# Altium schematic sheet Deck.SchDoc(Deck)
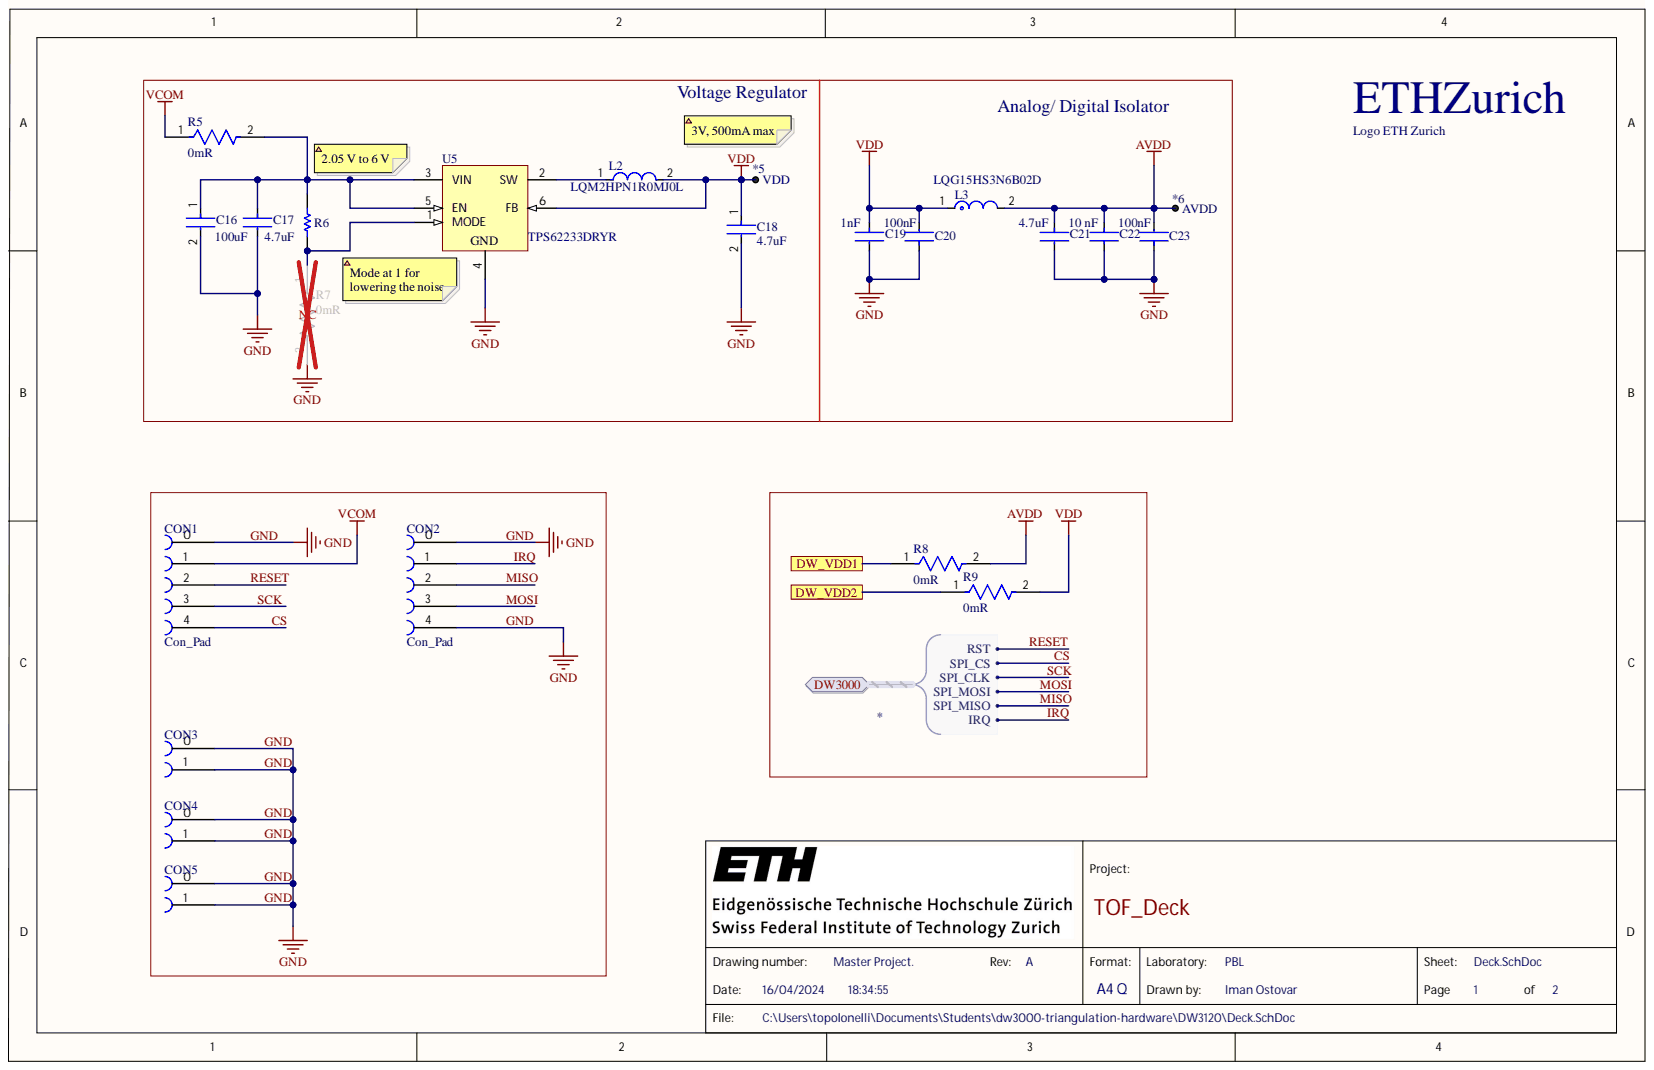
[diagram p0: Deck.SchDoc]

## Parts
*5  VDD  [Pad-1mm]  (no BOM)
*6  AVDD  [Pad-1mm]  (no BOM)
A1  Logo ETH Zurich  [eth-zurich_3mm]  (no BOM)
C16  Capacitance: 100uF  [FP-GRM21B-0_2-e0_2_0_7-IPC_A]  (hidden comment: GRM21BR60J107ME15L)
C17  Capacitance: 4.7uF  [FP-GRM155-0_1-IPC_B]  (hidden comment: GRM155R60J475ME47D)
C18  Capacitance: 4.7uF  [FP-GRM155-0_1-IPC_B]  (hidden comment: GRM155R60J475ME47D)
C19  Capacitance: 1nF  [FP-GRM155-0_05-IPC_B]  (hidden comment: GRM1555C1H102JA01D)
C20  Capacitance: 100nF  [FP-GRM155-0_05-IPC_B]  (hidden comment: GRM155R71E104ME14D)
C21  Capacitance: 4.7uF  [FP-GRM155-0_1-IPC_B]  (hidden comment: GRM155R60J475ME47D)
C22  value 10 nF  [FP-CC0402-MFG]  (hidden comment: CC0402KRX7R7BB103)
C23  Capacitance: 100nF  [FP-GRM155-0_05-IPC_B]  (hidden comment: GRM155R71E104ME14D)
CON1  Con_Pad  [Cons_Pad]
CON2  Con_Pad  [Cons_Pad]
CON3  Con_Pad_GND  [PCBComponent_1]
CON4  Con_Pad_GND  [PCBComponent_1]
CON5  Con_Pad_GND  [PCBComponent_1]
L2  LQM2HPN1R0MJ0L  [FP-LQM2HPN_J0-IPC_B]
L3  LQG15HS3N6B02D  [FP-LQG15HH_02-IPC_A]
R5  Resistance: 0mR  [FP-CRCW0402-e3-IPC_C]  (hidden comment: CRCW04020000Z0ED)
R6  CPF0402B47K5E1  [RESC1005X35X20LL05T10]  (value not drawn)
R7  Resistance: 0mR  [FP-CRCW0402-e3-IPC_C]  (hidden comment: CRCW04020000Z0ED)
R8  Resistance: 0mR  [FP-CRCW0402-e3-IPC_C]  (hidden comment: CRCW04020000Z0ED)
R9  Resistance: 0mR  [FP-CRCW0402-e3-IPC_C]  (hidden comment: CRCW04020000Z0ED)
U5  TPS62233DRYR  [DRY0006A]

## Ports
DW3000, DW3000.IRQ, DW3000.RST, DW3000.SPI_CLK, DW3000.SPI_CS, DW3000.SPI_MISO, DW3000.SPI_MOSI, DW_VDD1, DW_VDD2

## Nets
AVDD: *6.1 C21.1 C22.1 C23.2 L3.2 R8.2
VCOM: CON1.1 R5.1
VDD: *5.1 C18.1 C19.1 C20.2 L2.2 L3.1 R9.2 U5.6(FB)
CS: CON1.4 ; port DW3000
DW_VDD1: R8.1 ; port DW_VDD1
DW_VDD2: R9.1 ; port DW_VDD2
GND: C16.2 C17.2 C18.2 C19.2 C20.1 C21.2 C22.2 C23.1 CON1.0 CON2.0 CON2.4 CON3.0 CON3.1 CON4.0 CON4.1 CON5.0 CON5.1 R7.2 U5.4
IRQ: CON2.1 ; port DW3000
MISO: CON2.2 ; port DW3000
MOSI: CON2.3 ; port DW3000
RESET: CON1.2 ; port DW3000
SCK: CON1.3 ; port DW3000
NetC16_1: C16.1 C17.1 R5.2 R6.2 U5.3(VIN) U5.5(EN)
NetL2_1: L2.1 U5.2(SW)
NetR6_1: R6.1 R7.1 U5.1(MODE)

## Library data of the parts
*5 *6 = CMP-01283-1: 1mm SMT Test Pad; Library Name: ETHZ Vault; Mounting Technology: SMT
A1 = CMP-00038-1: Library Name: ETHZ Vault
C16 = CMP-2000-07599-2: Chip Multilayer Ceramic Capacitors for General Purpose, 0805, 100uF, X5R, 15%, 20%, 6.3V; Case Code (Imperial): 0805; Case Code (Metric): 2012; Case/Package: 0805; Component Kind: Standard; Dielectric: X5R; Lead Free: Lead Free; Length: 0.079inch; Library Name: Altium Content Vault; Max Operating Temperature: 85°C; Min Operating Temperature: -55°C; Mount: Surface Mount; Packaging: Cut Tape; REACH SVHC: No SVHC; Thickness: 0.057inch; Tolerance: 20%; Voltage Rating: 6.3V; Voltage Rating (DC): 6.3V; Width: 0.049inch
C17 C18 C21 = CMP-2008-04549-2: Chip Multilayer Ceramic Capacitors for General Purpose, 0402, 4.7uF, X5R, 15%, 20%, 6.3V; Case Code (Imperial): 0402; Case Code (Metric): 1005; Case/Package: 0402; Component Kind: Standard; Contact Plating: Tin; Depth: 0.5mm; Dielectric: X5R; Height: 0.5mm; Lead Free: Lead Free; Length: 1mm; Library Name: Altium Content Vault; Max Operating Temperature: 85°C; Min Operating Temperature: -55°C; Mount: Surface Mount; Package Quantity: 10000; Packaging: Tape and Reel; REACH SVHC: No SVHC; Termination: SMD/SMT; Thickness: 0.022inch; Tolerance: 20%; Voltage: 6.3V; Voltage Rating: 6.3V; Voltage Rating (DC): 6.3V; Weight: 0.000212oz; Width: 0.02inch
C19 = CMP-2008-04254-2: Chip Multilayer Ceramic Capacitors for General Purpose, 0402, 1000pF, C0G, 30ppm/°C, 5%, 50V; Insulation Resistance: 10GR; Case Code (Imperial): 0402; Case Code (Metric): 1005; Case/Package: 0402; Component Kind: Standard; Depth: 0.5mm; Dielectric: C0G; Dielectric Material: Ceramic; Height: 0.5mm; Lead Free: Lead Free; Length: 1mm; Library Name: Altium Content Vault; Material: Ceramic; Max Operating Temperature: 125°C; Min Operating Temperature: -55°C; Mount: Surface Mount; Number of Pins: 2; Package Quantity: 1; Packaging: Tape and Reel; Radiation Hardening: No; REACH SVHC: No SVHC; Temperature Coefficient: 30ppm/°C; Termination: SMD/SMT; Thickness: 0.022inch; Tolerance: 5%; Voltage: 50V; Voltage Rating: 50V; Voltage Rating (DC): 50V; Width: 0.5mm
C20 C23 = CMP-06035-053616-1: Chip Multilayer Ceramic Capacitors for General Purpose, 0402, 0.10uF, X7R, 15%, 20%, 25V; Case Code (Imperial): 0402; Case Code (Metric): 1005; Case/Package: 0402; Component Kind: Standard; Depth: 0.5mm; Dielectric: X7R; Height: 0.5mm; Lead Free: Lead Free; Length: 1mm; Library Name: Altium Content Vault; Material: Ceramic; Max Operating Temperature: 125°C; Min Operating Temperature: -55°C; Mount: Surface Mount; Package Quantity: 10000; Packaging: Tape and Reel; Resistor Type: Ceramic; Termination: SMD/SMT; Thickness: 0.022inch; Tolerance: 20%; Voltage Rating: 25V; Voltage Rating (DC): 25V; Weight: 0.000212oz; Width: 0.5mm
C22 = CMP-03422-000501-1: CAP CER 10000PF 16V X7R 0402; Manufacturer: YAGEO; Automotive Grade: NA; Capacitance: 10 nF; Material: Ceramic; Temperature Coefficient: 30ppm/°C; Tolerance: 0.1; Value: 10 nF; Automotive: No; Category: Cap; Component Kind: Standard; ComponentLink2Description: Datasheet; ComponentLink2URL: http://www.yageo.com/documents/recent/UPY-GP_NP0_16V-to-50V_16.pdf; Device Class L1: Passive Components; Device Class L2: Capacitors; Device Class L3: Ceramic Capacitors; Digikey Description: CAP CER 10000PF 16V X7R 0402; Footprint Url: http://www.yageo.com/exep/pages/download/literatures/UPY-C_GEN_24.pdf; Height: 0.55mm; Ipc Land Pattern Name: CAPC10050X50; Lead Free: Yes; Library Name: Altium Content Vault; Manufacturer Part Number: CC0402KRX7R7BB103; Mouser Description: 0402 10 nF 16 V ±10 % Tolerance X7R SMT Multilayer Ceramic Capacitor; Octopart: https://octopart.com/cc0402krx7r7bb103-yageo-192895; Package: CC0402; RoHS: TRUE; Temperature: -55°C to +125°C; Temperature Characteristic: X7R; Temperature Range High: +125°C; Temperature Range Low: -55°C; Voltage Rating: 16 V
L2 = CMP-1662-00025-2: FIXED IND 1UH 1.5A 90 MOHM SMD; Core Material: Ferrite; Current: 150mA; DC Resistance (DCR): 3.5R; Inductance Tolerance: 20%; Self Resonant Frequency: 70MHz; Shielding: Shielded; Test Frequency: 1MHz; Case Code (Imperial): 1008; Case Code (Metric): 2520; Case/Package: 1008; Component Kind: Standard; Current Rating: 1.5A; DC Current: 1.5A; Depth: 2.2mm; Height: 1.1mm; Inductance: 1uH; Lead Free: Lead Free; Length: 2.5mm; Library Name: Altium Content Vault; Max DC Current: 1.5A; Max Operating Temperature: 125°C; Military Standard: Not; Min Operating Temperature: -40°C; Mount: Surface Mount; Package Quantity: 3000; Packaging: Tape and Reel; Radiation Hardening: No; REACH SVHC: No SVHC; RoHS Compliant: Yes; Series: LQM2HP_J0; Termination: SMD/SMT; Tolerance: 20%; Width: 2mm
L3 = CMP-06042-005542-1: Multilayer type RF Inductor 3.6nH ±0.1nH 0.14Ω 750mA 0402; Component Kind: Standard; Library Name: Altium Content Vault
R5 R7-R9 = CMP-2002-08122-2: RES Thick Film, 0Ω, 0.063W, 0402; Max Power Dissipation: 63mW; Temperature Coefficient: 100ppm/°C; Tolerance: 5%; Voltage Rating: 50V; Case Code (Imperial): 0402; Case Code (Metric): 1005; Component Kind: Standard; Composition: Thick Film; Contact Plating: Tin; Depth: 0.5mm; Features: Automotive AEC-Q200; Height: 0.35mm; Lead Free: Lead Free; Length: 1mm; Library Name: Altium Content Vault; Max Operating Temperature: 155°C; Military Standard: Not; Min Operating Temperature: -55°C; Mount: Surface Mount; Number of Terminations: 2; Package Quantity: 1; Packaging: Tape and Reel; Power Rating: 63mW; Radiation Hardening: No; Termination: SMD/SMT; Voltage Rating (DC): 50V; Weight: 2.3E-05oz; Width: 0.5mm
  R5 R8 R9: Case/Package: 0402
R6 = CMP-2002-03309-1: 47.5k, CPF0402B47K5E1; Manufacturer: TE Connectivity / AMP; Component Kind: Standard; Library Name: Altium Content Vault; Part Number: CPF0402B47K5E1
U5 = CMP-0323-00281-3: Buck Step Down Regulator with 2.05 to 6 V Input and 3 V Output, -40 to 85 degC, 6-Pin SON (DRY), Green (RoHS & no Sb/Br); Manufacturer: Texas Instruments; Duty Cycle(Max)(%): 100; Iout(Max)(A): 0.5; Iq(Typ)(mA): 0.022; Preset Vout(V): 3; Rating: Catalog; Regulated Outputs(#): 1; SubFamily: Step-Down Regulator; Switch Current Limit(Typ)(A): 0.84; Synchronous Rectification: False; Vin(Max)(V): 6; Vin(Min)(V): 2.05; Component Kind: Standard; ComponentLink1Description: Datasheet; ComponentLink1URL: http://www.ti.com/general/docs/lit/getliterature.tsp?genericPartNumber=TPS62233&fileType=pdf; ComponentLink2Description: Package Specification; ComponentLink2URL: http://www.ti.com/litv/pdf/mpds221e; ComponentLink3Description: URL Link1; ComponentLink3URL: Samples URL:http://www.ti.com/product/tps62233#samples; DatasheetVersion: SLVS941E; Eco-Plan: Green (RoHS & no Sb/Br); Library Name: Altium Content Vault; Mounting Technology: Surface Mount; PackageDescription: 6-Pin Plastic Small Outline No-Lead, 1 x 1.45 x 0.6 mm Body, 0.5 mm Pitch; PackageReference: DRY0006A; PackageVersion: revF, Dec-2011; PartNumber: TPS62233DRYR; Topology: Buck; Vout(Max)(V): 3; Vout(Min)(V): 3
CON1 CON2 = Con_Pad: Component Kind: Standard; Library Name: Schlib2.SchLib
CON3-CON5 = Con_Pad_GND: Component Kind: Standard; Library Name: Schlib3.SchLib
